# Altium schematic sheet 4_ADDA_9250_9144.SchDoc
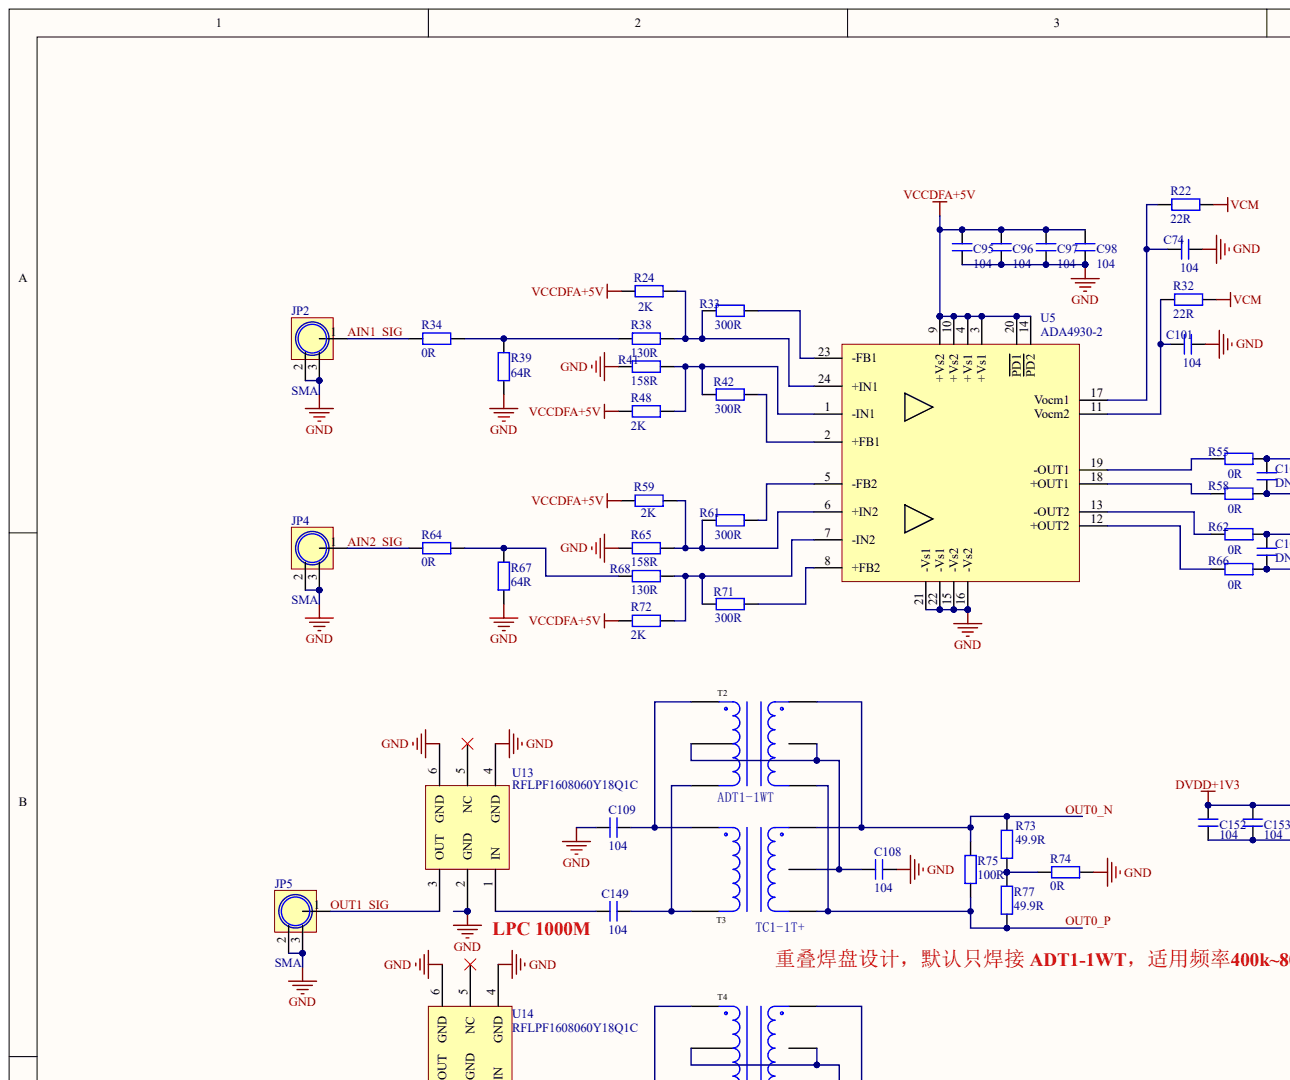
[diagram p0: 4_ADDA_9250_9144.SchDoc, top left]
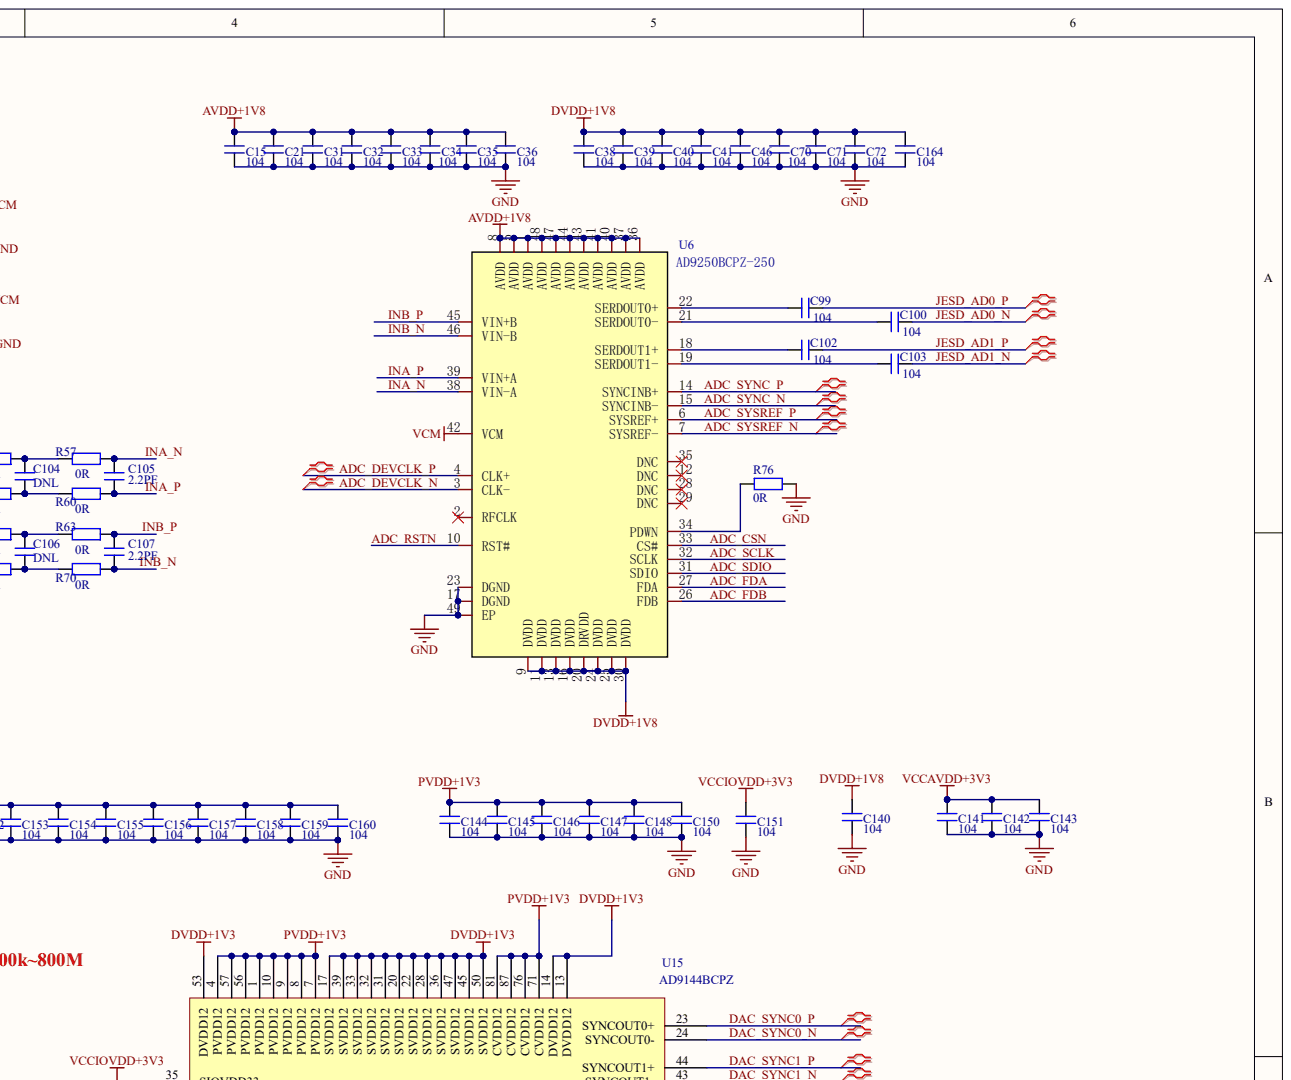
[diagram p1: 4_ADDA_9250_9144.SchDoc, top right]
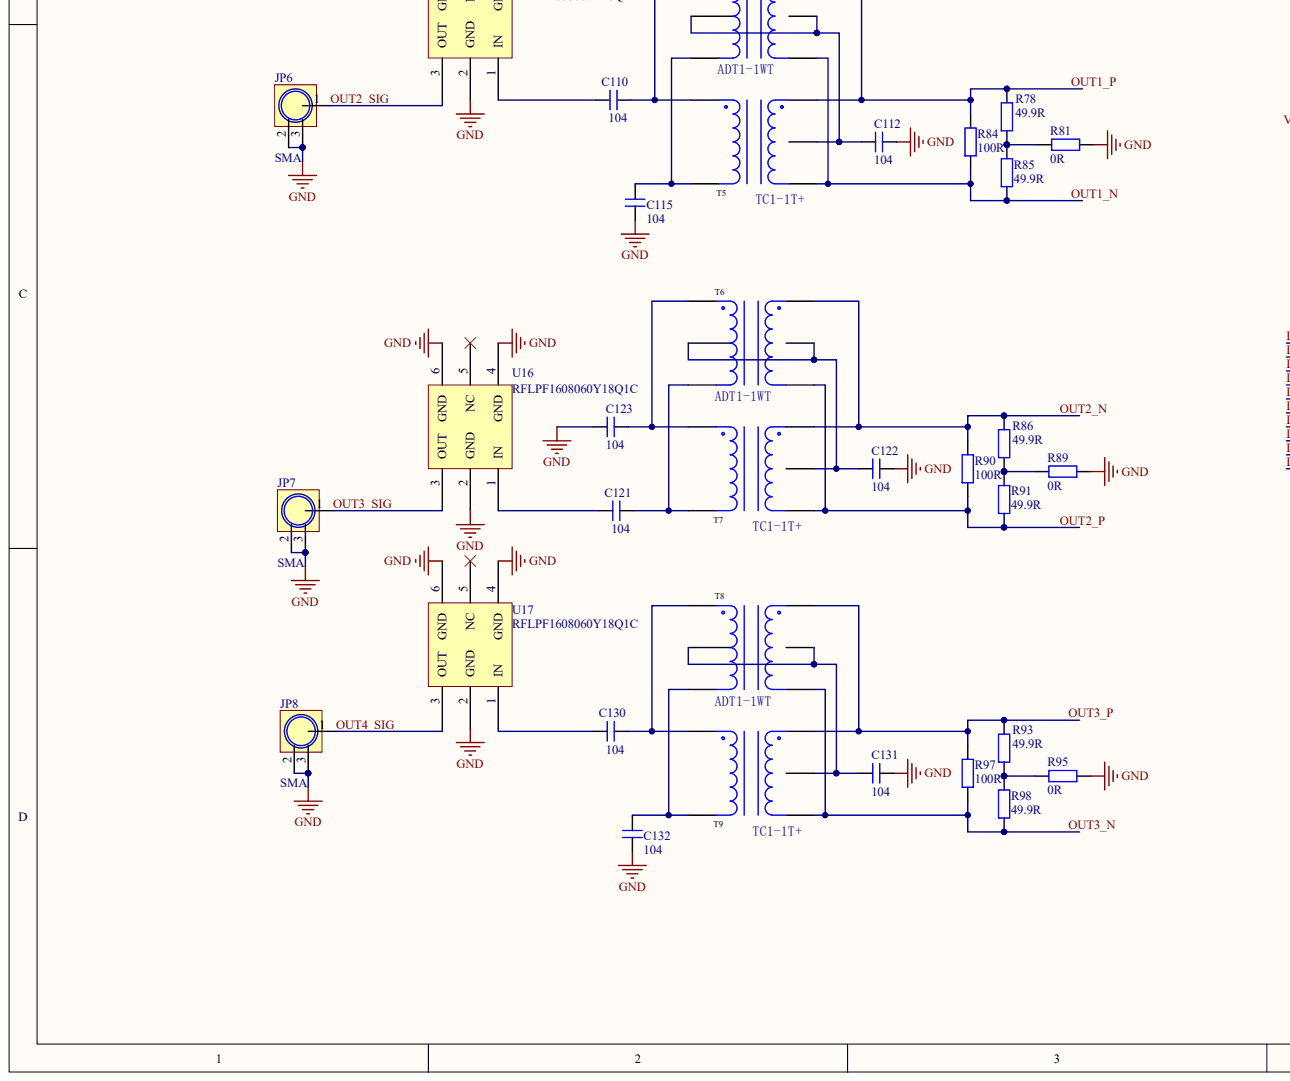
[diagram p2: 4_ADDA_9250_9144.SchDoc, bottom left]
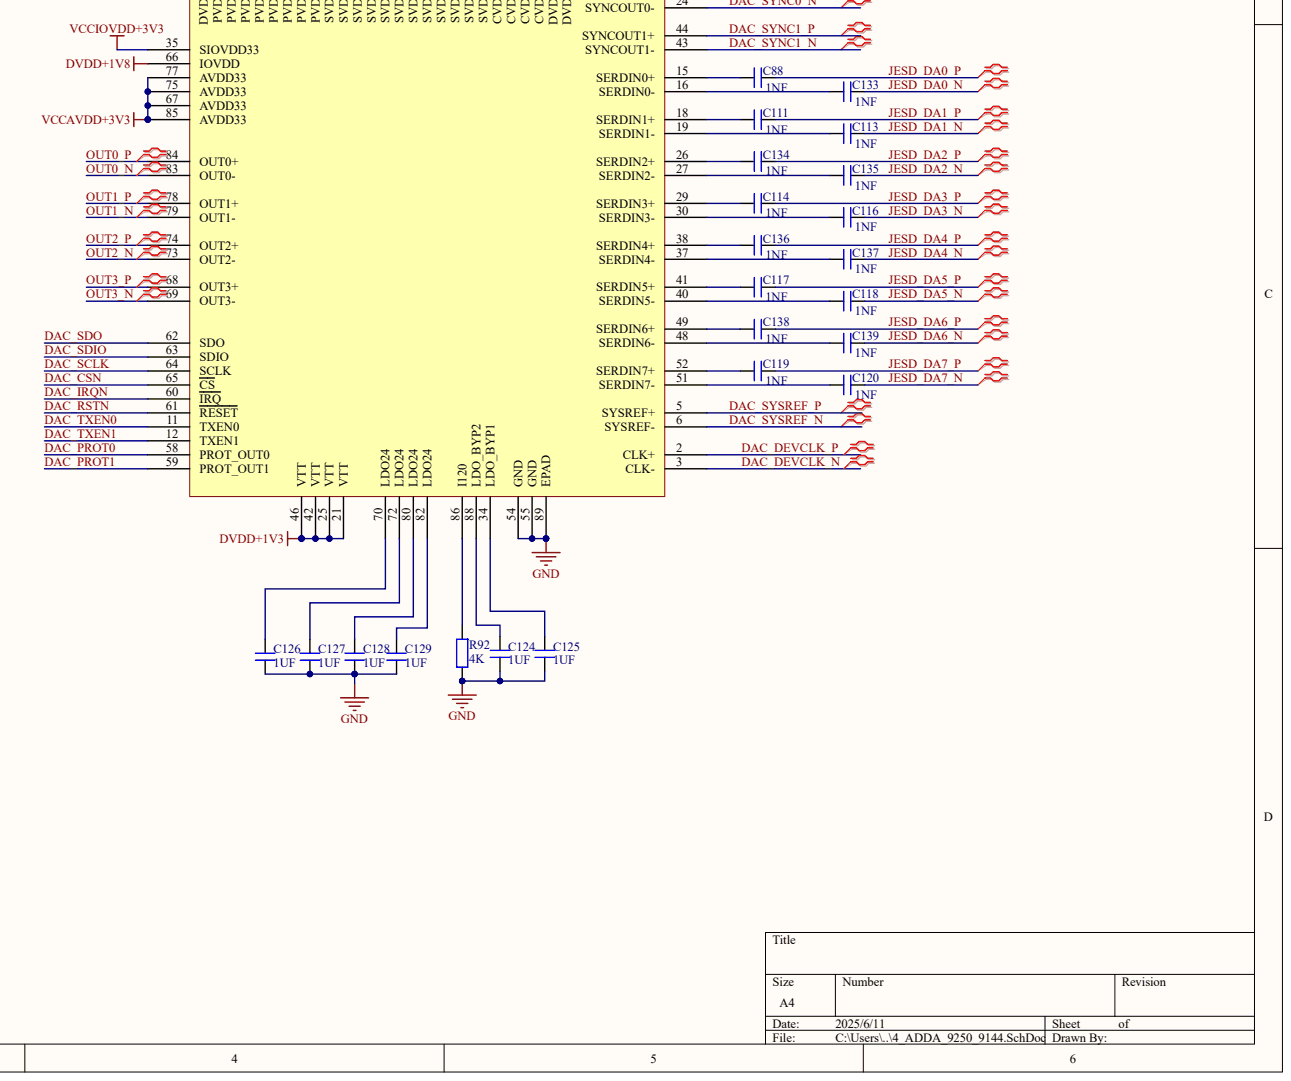
[diagram p3: 4_ADDA_9250_9144.SchDoc, bottom right]

## Parts
C15  104
C21  104
C31  104
C32  104
C33  104
C34  104
C35  104
C36  104
C38  104
C39  104
C40  104
C41  104
C46  104
C70  104
C71  104
C72  104
C74  104
C88  1NF
C95  104
C96  104
C97  104
C98  104
C99  104
C100  104
C101  104
C102  104
C103  104
C104  DNL
C105  2.2PF
C106  DNL
C107  2.2PF
C108  104
C109  104
C110  104
C111  1NF
C112  104
C113  1NF
C114  1NF
C115  104
C116  1NF
C117  1NF
C118  1NF
C119  1NF
C120  1NF
C121  104
C122  104
C123  104
C124  1UF
C125  1UF
C126  1UF
C127  1UF
C128  1UF
C129  1UF
C130  104
C131  104
C132  104
C133  1NF
C134  1NF
C135  1NF
C136  1NF
C137  1NF
C138  1NF
C139  1NF
C140  104
C141  104
C142  104
C143  104
C144  104
C145  104
C146  104
C147  104
C148  104
C149  104
C150  104
C151  104
C152  104
C153  104
C154  104
C155  104
C156  104
C157  104
C158  104
C159  104
C160  104
C164  104
JP2  SMA
JP4  SMA
JP5  SMA
JP6  SMA
JP7  SMA
JP8  SMA
R22  22R
R24  2K
R32  22R
R33  300R
R34  0R
R38  130R
R39  64R
R41  158R
R42  300R
R48  2K
R55  0R
R57  0R
R58  0R
R59  2K
R60  0R
R61  300R
R62  0R
R63  0R
R64  0R
R65  158R
R66  0R
R67  64R
R68  130R
R70  0R
R71  300R
R72  2K
R73  49.9R
R74  0R
R75  100R
R76  0R
R77  49.9R
R78  49.9R
R81  0R
R84  100R
R85  49.9R
R86  49.9R
R89  0R
R90  100R
R91  49.9R
R92  4K
R93  49.9R
R95  0R
R97  100R
R98  49.9R
T2  ADT1-1WT
T3  TC1-1T+
T4  ADT1-1WT
T5  TC1-1T+
T6  ADT1-1WT
T7  TC1-1T+
T8  ADT1-1WT
T9  TC1-1T+
U5  ADA4930-2
U6  AD9250BCPZ-250
U13  RFLPF1608060Y18Q1C
U14  RFLPF1608060Y18Q1C
U15  AD9144BCPZ
U16  RFLPF1608060Y18Q1C
U17  RFLPF1608060Y18Q1C

## Nets
AVDD+1V8: C15.2 C21.2 C31.2 C32.2 C33.2 C34.2 C35.2 C36.2 U6.1(AVDD) U6.5(AVDD) U6.8(AVDD) U6.36(AVDD) U6.37(AVDD) U6.40(AVDD) U6.41(AVDD) U6.43(AVDD) U6.44(AVDD) U6.47(AVDD) U6.48(AVDD)
DVDD+1V3: C152.2 C153.2 C154.2 C155.2 C156.2 C157.2 C158.2 C159.2 C160.2 U15.13(DVDD12) U15.14(DVDD12) U15.17(SVDD12) U15.20(SVDD12) U15.21(VTT) U15.22(SVDD12) U15.25(VTT) U15.28(SVDD12) U15.31(SVDD12) U15.32(SVDD12) U15.33(SVDD12) U15.36(SVDD12) U15.39(SVDD12) U15.42(VTT) U15.45(SVDD12) U15.46(VTT) U15.47(SVDD12) U15.50(SVDD12) U15.53(DVDD12)
DVDD+1V8: C38.2 C39.2 C40.2 C41.2 C46.2 C70.2 C71.2 C72.2 C140.2 C164.2 U6.9(DVDD) U6.11(DVDD) U6.13(DVDD) U6.16(DVDD) U6.20(DRVDD) U6.24(DVDD) U6.25(DVDD) U6.30(DVDD) U15.66(IOVDD)
GND: C15.1 C21.1 C31.1 C32.1 C33.1 C34.1 C35.1 C36.1 C38.1 C39.1 C40.1 C41.1 C46.1 C70.1 C71.1 C72.1 C74.1 C95.1 C96.1 C97.1 C98.1 C101.1 C108.1 C109.1 C112.1 C115.2 C122.1 C123.1 C124.2 C125.2 C126.2 C127.2 C128.2 C129.2 C131.1 C132.2 C140.1 C141.1 C142.1 C143.1 C144.1 C145.1 C146.1 C147.1 C148.1 C150.1 C151.1 C152.1 C153.1 C154.1 C155.1 C156.1 C157.1 C158.1 C159.1 C160.1 C164.1 JP2.2 JP2.3 JP4.2 JP4.3 JP5.2 JP5.3 JP6.2 JP6.3 JP7.2 JP7.3 JP8.2 JP8.3 R39.2 R41.2 R65.2 R67.2 R74.2 R76.1 R81.2 R89.2 R92.1 R95.2 U5.15(-Vs2) U5.16(-Vs2) U5.21(-Vs1) U5.22(-Vs1) U5.25 U6.17(DGND) U6.23(DGND) U6.49(EP) U13.2(GND) U13.4(GND) U13.6(GND) U14.2(GND) U14.4(GND) U14.6(GND) U15.54(GND) U15.55(GND) U15.89(EPAD) U16.2(GND) U16.4(GND) U16.6(GND) U17.2(GND) U17.4(GND) U17.6(GND)
PVDD+1V3: C144.2 C145.2 C146.2 C147.2 C148.2 C150.2 U15.1(PVDD12) U15.4(PVDD12) U15.7(PVDD12) U15.8(PVDD12) U15.9(PVDD12) U15.10(PVDD12) U15.56(PVDD12) U15.57(PVDD12) U15.71(CVDD12) U15.76(CVDD12) U15.81(CVDD12) U15.87(CVDD12)
VCCAVDD+3V3: C141.2 C142.2 C143.2 U15.67(AVDD33) U15.75(AVDD33) U15.77(AVDD33) U15.85(AVDD33)
VCCDFA+5V: C95.2 C96.2 C97.2 C98.2 R24.1 R48.1 R59.1 R72.1 U5.3(+Vs1) U5.4(+Vs1) U5.9(+Vs2) U5.10(+Vs2) U5.14(PD2) U5.20(PD1)
VCCIOVDD+3V3: C151.2 U15.35(SIOVDD33)
VCM: R22.2 R32.2 U6.42(VCM)
ADC_CSN: U6.33(CS#)
ADC_DEVCLK_N: U6.3(CLK-)
ADC_DEVCLK_P: U6.4(CLK+)
ADC_FDA: U6.27(FDA)
ADC_FDB: U6.26(FDB)
ADC_RSTN: U6.10(RST#)
ADC_SCLK: U6.32(SCLK)
ADC_SDIO: U6.31(SDIO)
ADC_SYNC_N: U6.15(SYNCINB-)
ADC_SYNC_P: U6.14(SYNCINB+)
ADC_SYSREF_N: U6.7(SYSREF-)
ADC_SYSREF_P: U6.6(SYSREF+)
AIN1_SIG: JP2.1 R34.1
AIN2_SIG: JP4.1 R64.1
DAC_CSN: U15.65(CS)
DAC_DEVCLK_N: U15.3(CLK-)
DAC_DEVCLK_P: U15.2(CLK+)
DAC_IRQN: U15.60(IRQ)
DAC_PROT0: U15.58(PROT_OUT0)
DAC_PROT1: U15.59(PROT_OUT1)
DAC_RSTN: U15.61(RESET)
DAC_SCLK: U15.64(SCLK)
DAC_SDIO: U15.63(SDIO)
DAC_SDO: U15.62(SDO)
DAC_SYNC0_N: U15.24(SYNCOUT0-)
DAC_SYNC0_P: U15.23(SYNCOUT0+)
DAC_SYNC1_N: U15.43(SYNCOUT1-)
DAC_SYNC1_P: U15.44(SYNCOUT1+)
DAC_SYSREF_N: U15.6(SYSREF-)
DAC_SYSREF_P: U15.5(SYSREF+)
DAC_TXEN0: U15.11(TXEN0)
DAC_TXEN1: U15.12(TXEN1)
INA_N: C105.1 R57.2 U6.38(VIN-A)
INA_P: C105.2 R60.2 U6.39(VIN+A)
INB_N: C107.2 R70.2 U6.46(VIN-B)
INB_P: C107.1 R63.2 U6.45(VIN+B)
JESD_AD0_N: C100.2
JESD_AD0_P: C99.1
JESD_AD1_N: C103.2
JESD_AD1_P: C102.1
JESD_DA0_N: C133.2
JESD_DA0_P: C88.1
JESD_DA1_N: C113.2
JESD_DA1_P: C111.1
JESD_DA2_N: C135.2
JESD_DA2_P: C134.1
JESD_DA3_N: C116.2
JESD_DA3_P: C114.1
JESD_DA4_N: C137.2
JESD_DA4_P: C136.1
JESD_DA5_N: C118.2
JESD_DA5_P: C117.1
JESD_DA6_N: C139.2
JESD_DA6_P: C138.1
JESD_DA7_N: C120.2
JESD_DA7_P: C119.1
OUT0_N: R73.1 R75.1 T2.3 T3.1 U15.83(OUT0-)
OUT0_P: R75.2 R77.2 T2.1 T3.3 U15.84(OUT0+)
OUT1_N: R84.2 R85.2 T4.1 T5.3 U15.79(OUT1-)
OUT1_P: R78.1 R84.1 T4.3 T5.1 U15.78(OUT1+)
OUT1_SIG: JP5.1 U13.3(OUT)
OUT2_N: R86.1 R90.1 T6.3 T7.1 U15.73(OUT2-)
OUT2_P: R90.2 R91.2 T6.1 T7.3 U15.74(OUT2+)
OUT2_SIG: JP6.1 U14.3(OUT)
OUT3_N: R97.2 R98.2 T8.1 T9.3 U15.69(OUT3-)
OUT3_P: R93.1 R97.1 T8.3 T9.1 U15.68(OUT3+)
OUT3_SIG: JP7.1 U16.3(OUT)
OUT4_SIG: JP8.1 U17.3(OUT)
NetC74_2: C74.2 R22.1 U5.17(Vocm1)
NetC88_2: C88.2 U15.15(SERDIN0+)
NetC99_2: C99.2 U6.22(SERDOUT0+)
NetC100_1: C100.1 U6.21(SERDOUT0-)
NetC101_2: C101.2 R32.1 U5.11(Vocm2)
NetC102_2: C102.2 U6.18(SERDOUT1+)
NetC103_1: C103.1 U6.19(SERDOUT1-)
NetC104_1: C104.1 R55.2 R57.1
NetC104_2: C104.2 R58.2 R60.1
NetC106_1: C106.1 R62.2 R63.1
NetC106_2: C106.2 R66.2 R70.1
NetC108_2: C108.2 T2.2 T2.5 T3.2
NetC109_2: C109.2 T2.4 T3.6
NetC110_1: C110.1 U14.1(IN)
NetC110_2: C110.2 T4.4 T5.6
NetC111_2: C111.2 U15.18(SERDIN1+)
NetC112_2: C112.2 T4.2 T4.5 T5.2
NetC113_1: C113.1 U15.19(SERDIN1-)
NetC114_2: C114.2 U15.29(SERDIN3+)
NetC115_1: C115.1 T4.6 T5.4
NetC116_1: C116.1 U15.30(SERDIN3-)
NetC117_2: C117.2 U15.41(SERDIN5+)
NetC118_1: C118.1 U15.40(SERDIN5-)
NetC119_2: C119.2 U15.52(SERDIN7+)
NetC120_1: C120.1 U15.51(SERDIN7-)
NetC121_1: C121.1 U16.1(IN)
NetC121_2: C121.2 T6.6 T7.4
NetC122_2: C122.2 T6.2 T6.5 T7.2
NetC123_2: C123.2 T6.4 T7.6
NetC124_1: C124.1 U15.88(LDO_BYP2)
NetC125_1: C125.1 U15.34(LDO_BYP1)
NetC126_1: C126.1 U15.70(LDO24)
NetC127_1: C127.1 U15.72(LDO24)
NetC128_1: C128.1 U15.80(LDO24)
NetC129_1: C129.1 U15.82(LDO24)
NetC130_1: C130.1 U17.1(IN)
NetC130_2: C130.2 T8.4 T9.6
NetC131_2: C131.2 T8.2 T8.5 T9.2
NetC132_1: C132.1 T8.6 T9.4
NetC133_1: C133.1 U15.16(SERDIN0-)
NetC134_2: C134.2 U15.26(SERDIN2+)
NetC135_1: C135.1 U15.27(SERDIN2-)
NetC136_2: C136.2 U15.38(SERDIN4+)
NetC137_1: C137.1 U15.37(SERDIN4-)
NetC138_2: C138.2 U15.49(SERDIN6+)
NetC139_1: C139.1 U15.48(SERDIN6-)
NetC149_1: C149.1 U13.1(IN)
NetC149_2: C149.2 T2.6 T3.4
NetR24_2: R24.2 R33.2 R38.1 U5.24(+IN1)
NetR33_1: R33.1 U5.23(-FB1)
NetR34_2: R34.2 R38.2 R39.1
NetR41_1: R41.1 R42.2 R48.2 U5.1(-IN1)
NetR42_1: R42.1 U5.2(+FB1)
NetR55_1: R55.1 U5.19(-OUT1)
NetR58_1: R58.1 U5.18(+OUT1)
NetR59_2: R59.2 R61.2 R65.1 U5.6(+IN2)
NetR61_1: R61.1 U5.5(-FB2)
NetR62_1: R62.1 U5.13(-OUT2)
NetR64_2: R64.2 R67.1 R68.2
NetR66_1: R66.1 U5.12(+OUT2)
NetR68_1: R68.1 R71.2 R72.2 U5.7(-IN2)
NetR71_1: R71.1 U5.8(+FB2)
NetR73_2: R73.2 R74.1 R77.1
NetR76_2: R76.2 U6.34(PDWN)
NetR78_2: R78.2 R81.1 R85.1
NetR86_2: R86.2 R89.1 R91.1
NetR92_2: R92.2 U15.86(I120)
NetR93_2: R93.2 R95.1 R98.1

Not connected: U6 2(RFCLK) 12(DNC) 28(DNC) 29(DNC) 35(DNC); U13 5(NC); U14 5(NC); U16 5(NC); U17 5(NC)

## Library data of the parts
JP2 JP4-JP8 = (no symbol name): BOM名称: SMA-2.4G射频天线接口; 唯一出售店铺网址: https://shop463601444.taobao.com
R22 R24 R32-R34 R38 R39 R41 R42 R48 R55 R57-R68 R70-R78 R81 R84-R86 R89-R93 R95 R97 R98 = (no symbol name): BOM名称: 贴片电阻; : 联系淘宝ID：黄晶宝石，获取更新版封装库; : 唯一出售店铺网址https://shop463601444.taobao.com
T2 T4 T6 T8 = (no symbol name): Manufacturer: Mini-Circuits; Name: TC1-1T+; Add into BOM: yes; Symbol: TC1-1T+; Device: TC1-1T+; LCSC Part Name: TC1-1T+; Supplier Part: C879831; Manufacturer Part: TC1-1T+; Supplier Footprint: SMD,3.81x3.81x4.06mm; JLCPCB Part Class: Extended Part; Datasheet: https://atta.szlcsc.com/upload/public/pdf/source/20201013/C879831_54F63EAB507C5E12C50BC96C0685F0E3.pdf; Supplier: LCSC; Convert to PCB: yes
T3 T5 T7 T9 = (no symbol name): Manufacturer: Mini-Circuits; Add into BOM: yes; Name: TC1-1T+; Symbol: TC1-1T+; Device: TC1-1T+; LCSC Part Name: TC1-1T+; Supplier Part: C879831; Manufacturer Part: TC1-1T+; Supplier Footprint: SMD,3.81x3.81x4.06mm; JLCPCB Part Class: Extended Part; Datasheet: https://atta.szlcsc.com/upload/public/pdf/source/20201013/C879831_54F63EAB507C5E12C50BC96C0685F0E3.pdf; Supplier: LCSC; Convert to PCB: yes
U6 = (no symbol name): Manufacturer: ADI(亚德诺)/LINEAR(凌特); Add into BOM: yes; Name: AD9250BCPZ-250; Symbol: AD9250BCPZ-250; Device: AD9250BCPZ-250; LCSC Part Name: AD9250BCPZ-250; Supplier Part: C653375; Manufacturer Part: AD9250BCPZ-250; Supplier Footprint: LFCSP-48; JLCPCB Part Class: Extended Part; Datasheet: https://atta.szlcsc.com/upload/public/pdf/source/20220519/78D0D97AF3E510E3C028D59F3469FE5E.pdf; Supplier: LCSC; Convert to PCB: yes
C15 C21 C31-C36 C38-C41 C46 C70-C72 C74 C88 C95-C160 C164 U5 U13-U17 = (no symbol name)
  C15 C21 C31-C36 C38-C41 C46 C70-C72 C74 C88 C95-C160 C164: : 联系淘宝ID：黄晶宝石，获取更新版封装库; : 唯一出售店铺网址https://shop463601444.taobao.com
